# Altium schematic sheet main.SchDoc
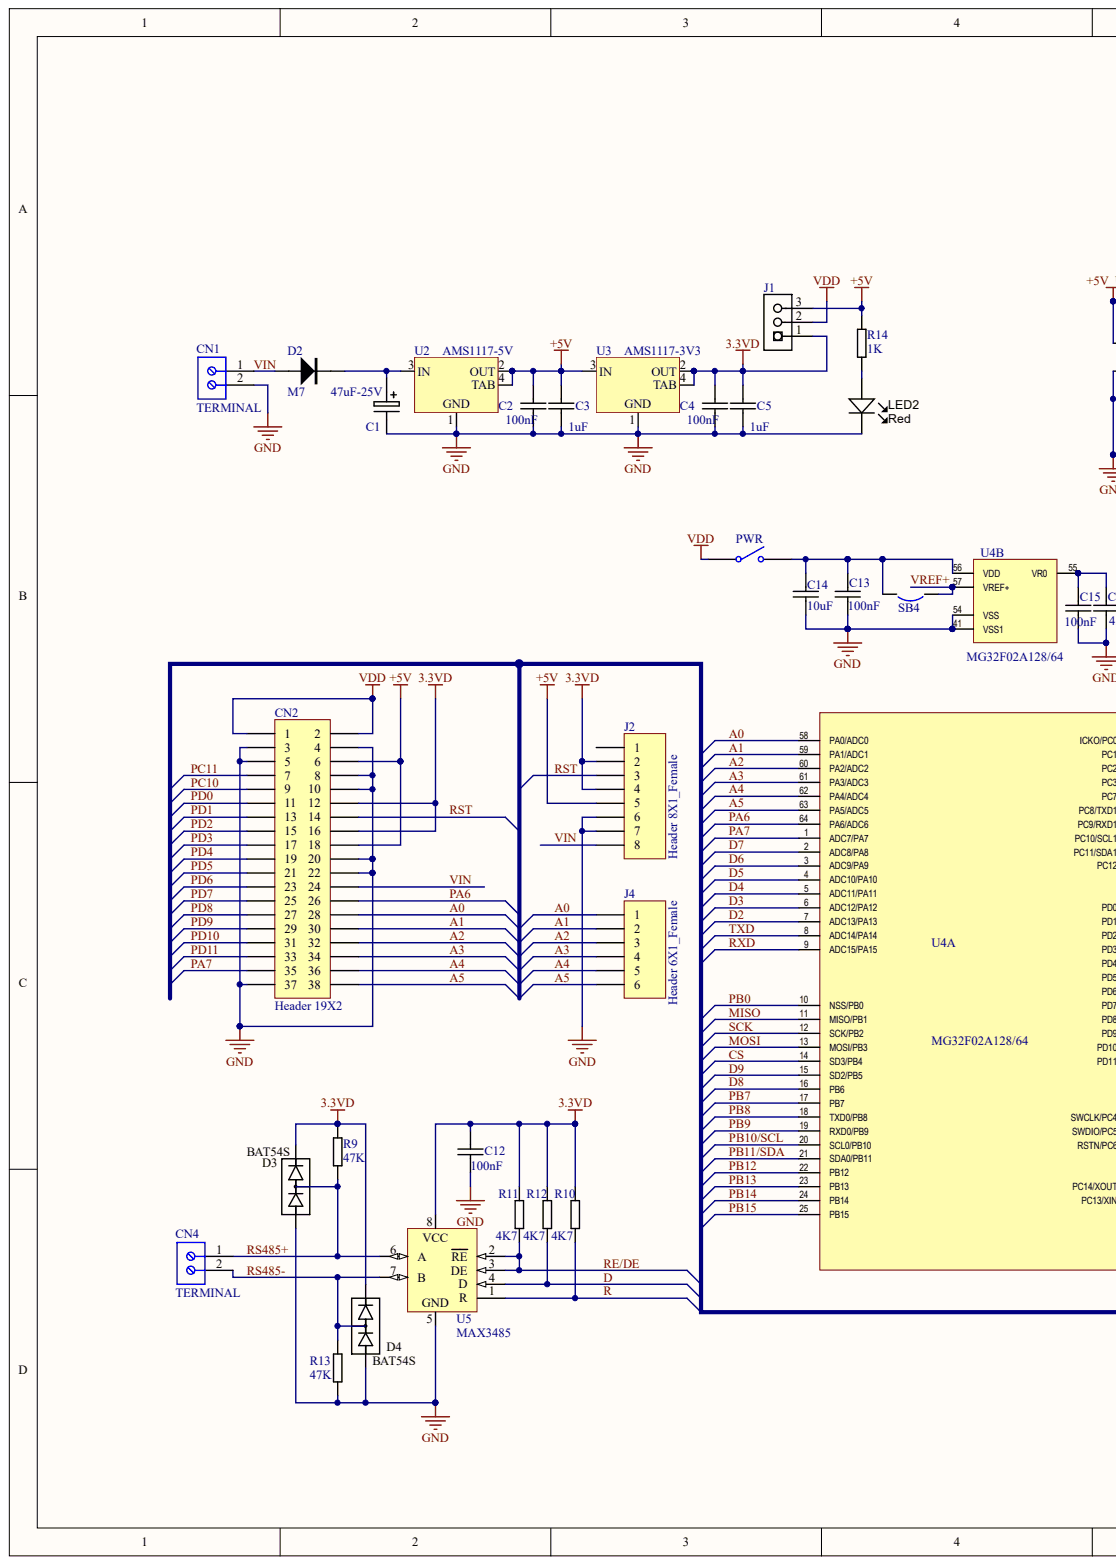
[diagram p0: main.SchDoc, left]
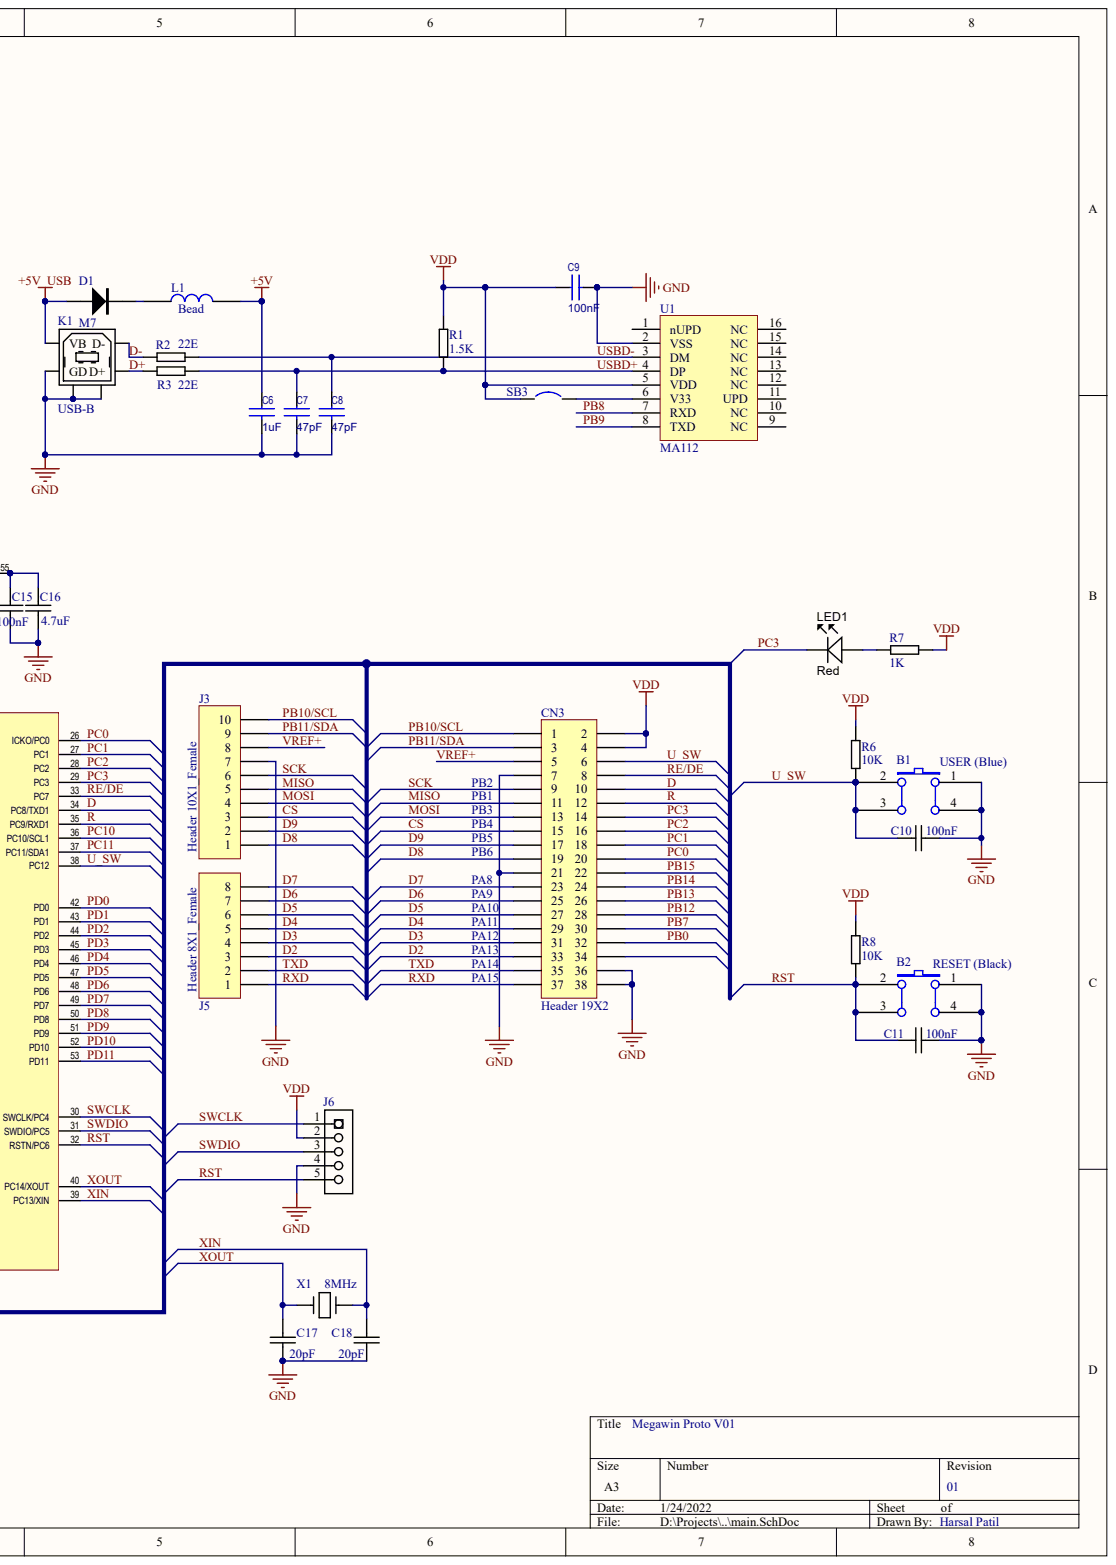
[diagram p1: main.SchDoc, right]

## Parts
B1  USER (Blue)
B2  RESET (Black)
C1  47uF-25V
C2  100nF
C3  1uF
C4  100nF
C5  1uF
C6  1uF
C7  47pF
C8  47pF
C9  100nF
C10  100nF
C11  100nF
C12  100nF
C13  100nF
C14  10uF
C15  100nF
C16  4.7uF
C17  20pF
C18  20pF
CN1  TERMINAL
CN2  Header 19X2
CN3  Header 19X2
CN4  TERMINAL
D1  M7
D2  M7
D3  BAT54S
D4  BAT54S
J1  Header 3X1_male  (value not drawn)
J2  Header 8X1_Female
J3  Header 10X1_Female
J4  Header 6X1_Female
J5  Header 8X1_Female
J6  HEADER-1X5  (value not drawn)
K1  USB-B
L1  Bead
LED1  Color: Red
LED2  Color: Red
PWR  SW-SPST  (value not drawn)
R1  1.5K
R2  22E
R3  22E
R6  10K
R7  1K
R8  10K
R9  47K
R10  4K7
R11  4K7
R12  4K7
R13  47K
R14  1K
SB3  Circuit Breaker  (value not drawn)
SB4  Circuit Breaker  (value not drawn)
U1  MA112
U2  AMS1117-5V
U3  AMS1117-3V3
U4  MG32F02A128/64  (parts A,B)
U5  MAX3485
X1  8MHz

## Nets
3.3VD: C4.1 C5.1 C12.1 CN2.12 CN2.16 D3.2 D4.2 J1.1 J2.2 J2.4 R9.1 R10.1 R11.1 R12.1 U3.2(OUT) U3.4(TAB) U5.8
+5V: C2.1 C3.1 C6.1 CN2.6 CN2.18 J1.3 J2.5 L1.2 R14.2 U2.2(OUT) U2.4(TAB) U3.3(IN)
+5V_USB: D1.2 K1.1(VB)
GND: B1.1 B1.4 B2.1 B2.4 C1.- C2.2 C3.2 C4.2 C5.2 C6.2 C7.2 C8.2 C9.2 C10.2 C11.2 C12.2 C13.2 C14.1 C15.2 C16.2 C17.2 C18.2 CN1.2 CN2.3 CN2.4 CN2.5 CN2.8 CN2.10 CN2.20 CN2.22 CN2.37 CN3.7 CN3.21 CN3.36 CN3.38 D3.1 D4.1 J2.6 J2.7 J3.7 J6.4 K1.4 K1.5(GD) K1.6(D+) LED2.K R13.2 U1.2(VSS) U2.1 U3.1 U4.41(VSS1) U4.54(VSS) U5.5
VDD: C9.1 CN2.1 CN2.2 CN3.2 CN3.4 J1.2 J6.2 PWR.1 R1.2 R6.2 R7.2 R8.2 SB3.1 U1.5(VDD)
A0: CN2.28 J4.1 U4.58(PA0/ADC0)
A1: CN2.30 J4.2 U4.59(PA1/ADC1)
A2: CN2.32 J4.3 U4.60(PA2/ADC2)
A3: CN2.34 J4.4 U4.61(PA3/ADC3)
A4: CN2.36 J4.5 U4.62(PA4/ADC4)
A5: CN2.38 J4.6 U4.63(PA5/ADC5)
CS: CN3.15 J3.3 U4.14(SD3/PB4)
D: CN3.10 R12.2 U4.34(PC8/TXD1) U5.4(D)
D2: CN3.33 J5.3 U4.7(ADC13/PA13)
D3: CN3.31 J5.4 U4.6(ADC12/PA12)
D4: CN3.29 J5.5 U4.5(ADC11/PA11)
D5: CN3.27 J5.6 U4.4(ADC10/PA10)
D6: CN3.25 J5.7 U4.3(ADC9/PA9)
D7: CN3.23 J5.8 U4.2(ADC8/PA8)
D8: CN3.19 J3.1 U4.16(PB6)
D9: CN3.17 J3.2 U4.15(SD2/PB5)
D+: K1.3 R3.1
D-: K1.2(D-) R2.1
MISO: CN3.11 J3.5 U4.11(MISO/PB1)
MOSI: CN3.13 J3.4 U4.13(MOSI/PB3)
PA6: CN2.26 U4.64(PA6/ADC6)
PA7: CN2.35 U4.1(ADC7/PA7)
PB0: CN3.32 U4.10(NSS/PB0)
PB7: CN3.30 U4.17(PB7)
PB8: U1.7(RXD) U4.18(TXD0/PB8)
PB9: U1.8(TXD) U4.19(RXD0/PB9)
PB10/SCL: CN3.1 J3.10 U4.20(SCL0/PB10)
PB11/SDA: CN3.3 J3.9 U4.21(SDA0/PB11)
PB12: CN3.28 U4.22(PB12)
PB13: CN3.26 U4.23(PB13)
PB14: CN3.24 U4.24(PB14)
PB15: CN3.22 U4.25(PB15)
PC0: CN3.20 U4.26(ICKO/PC0)
PC1: CN3.18 U4.27(PC1)
PC2: CN3.16 U4.28(PC2)
PC3: CN3.14 LED1.K U4.29(PC3)
PC10: CN2.9 U4.36(PC10/SCL1)
PC11: CN2.7 U4.37(PC11/SDA1)
PD0: CN2.11 U4.42(PD0)
PD1: CN2.13 U4.43(PD1)
PD2: CN2.15 U4.44(PD2)
PD3: CN2.17 U4.45(PD3)
PD4: CN2.19 U4.46(PD4)
PD5: CN2.21 U4.47(PD5)
PD6: CN2.23 U4.48(PD6)
PD7: CN2.25 U4.49(PD7)
PD8: CN2.27 U4.50(PD8)
PD9: CN2.29 U4.51(PD9)
PD10: CN2.31 U4.52(PD10)
PD11: CN2.33 U4.53(PD11)
R: CN3.12 R10.2 U4.35(PC9/RXD1) U5.1(R)
RE/DE: CN3.8 R11.2 U4.33(PC7) U5.2(RE) U5.3(DE)
RS485+: CN4.1 D3.3 R9.2 U5.6(A)
RS485-: CN4.2 D4.3 R13.1 U5.7(B)
RST: B2.2 B2.3 C11.1 CN2.14 J2.3 J6.5 R8.1 U4.32(RSTN/PC6)
RXD: CN3.37 J5.1 U4.9(ADC15/PA15)
SCK: CN3.9 J3.6 U4.12(SCK/PB2)
SWCLK: J6.1 U4.30(SWCLK/PC4)
SWDIO: J6.3 U4.31(SWDIO/PC5)
TXD: CN3.35 J5.2 U4.8(ADC14/PA14)
U_SW: B1.2 B1.3 C10.1 CN3.6 R6.1 U4.38(PC12)
USBD+: C7.1 R1.1 R3.2 U1.4(DP)
USBD-: C8.1 R2.2 U1.3(DM)
VIN: CN1.1 CN2.24 D2.2 J2.8
VREF+: CN3.5 J3.8 SB4.1 U4.57(VREF+)
XIN: C18.1 U4.39(PC13/XIN) X1.1
XOUT: C17.1 U4.40(PC14/XOUT) X1.2
NetC1_+: C1.+ D2.1 U2.3(IN)
NetC13_1: C13.1 C14.2 PWR.2 SB4.2 U4.56(VDD)
NetC15_1: C15.1 C16.1 U4.55(VR0)
NetD1_1: D1.1 L1.1
NetLED1_A: LED1.A R7.1
NetLED2_A: LED2.A R14.1
NetSB3_2: SB3.2 U1.6(V33)
N00025:

Not connected: CN3 34; J2 1; U1 1(nUPD) 9(NC) 10(NC) 11(UPD) 12(NC) 13(NC) 14(NC) 15(NC) 16(NC)

## Library data of the parts
CN1 CN4 = (no symbol name): Part Number: 691213510002; Compliance: ROHS & Reach; Modularity: Yes; PCB/Cable/Panel: PCB; Pin Number: 2; Pitch: 5.08mm; Rated Current [UL]: 14A; Working Voltage [UL]: 300VAC; ComponentLink1Description: Manufacturer; ComponentLink1URL: http://www.we-online.de; ComponentLink2URL: https://katalog.we-online.de/em/datasheet/691213510002.pdf; ComponentLink2Description: Datasheet; Mounting Technology: THT; Packaging: Box; Operating Temperature: -30 to +120degC; Published Date: October 2019; Type: Horizontal; Application: Rising Cage Clamp; Publisher: Wurth Elektronik
J6 = (no symbol name): REEL: TH; Supplier 1: Digi-Key; Supplier Part Number 1: S1011E-36-ND
K1 = (no symbol name): Text Field1: Assmann; Text Field2: AU-Y1007; Text Field3: Digi-Key; Text Field4: AE1085-ND; Text Field5: Thru-Hole; Text Field6: 1.168; NI?: *; O?: *; Section:: *
LED1 LED2 = (no symbol name): Manufacturer 1: Lite-On Inc; Manufacturer Part Number 1: LTST-C171EKT; Material: GaAsP on GaP; Ratings: 6 mcd; 621 nm; Value: Red; SCDType: source; Package / Case: 0805; Supplier 1: Digi-Key; Supplier Part Number 1: 160-1422-1-ND; Comment: =Value
SB3 SB4 = (no symbol name): Solder: NO; Published: 24-Mar-1999; Revision: July-2002: Re-released for DXP Platform.; Publisher: Altium Limited
B1 B2 C1-C18 CN2 CN3 D1-D4 J1-J5 L1 PWR R1-R3 R6-R14 U1-U5 X1 = (no symbol name)
  C1: Supplier 1: Digi-Key; Supplier Part Number 1: PCE3804TR-ND
  C2-C5 C10-C18: Supplier 1: Digi-Key; Supplier Part Number 1: 490-1673-1-ND
  CN2 CN3: Revision: 17-Jul-2002: Re-released for DXP Platform.
  D1 D2: Supplier 1: Digi-Key; Supplier Part Number 1: MBRS140FSTR-ND
  D3 D4: Supplier 1: Digi-Key; Supplier Part Number 1: BAV99FSTR-ND
  J1: Supplier 1: Digi-Key; Supplier Part Number 1: S1011E-36-ND
  J2-J5: LatestRevisionDate: 17-Jul-2002; LatestRevisionNote: Re-released for DXP Platform.
  PWR: Published: 24-Mar-1999; LatestRevisionDate: 17-Jul-2002; LatestRevisionNote: Re-released for DXP Platform.; PackageReference: SPST-2; PackageDescription: Switch; 2 Leads
  R1-R3 R6-R14: Reel: *
  CN2 CN3 J2-J5 PWR: Publisher: Altium Limited
